# Altium schematic sheet MCU_ascii.SchDoc(MCU_ascii)
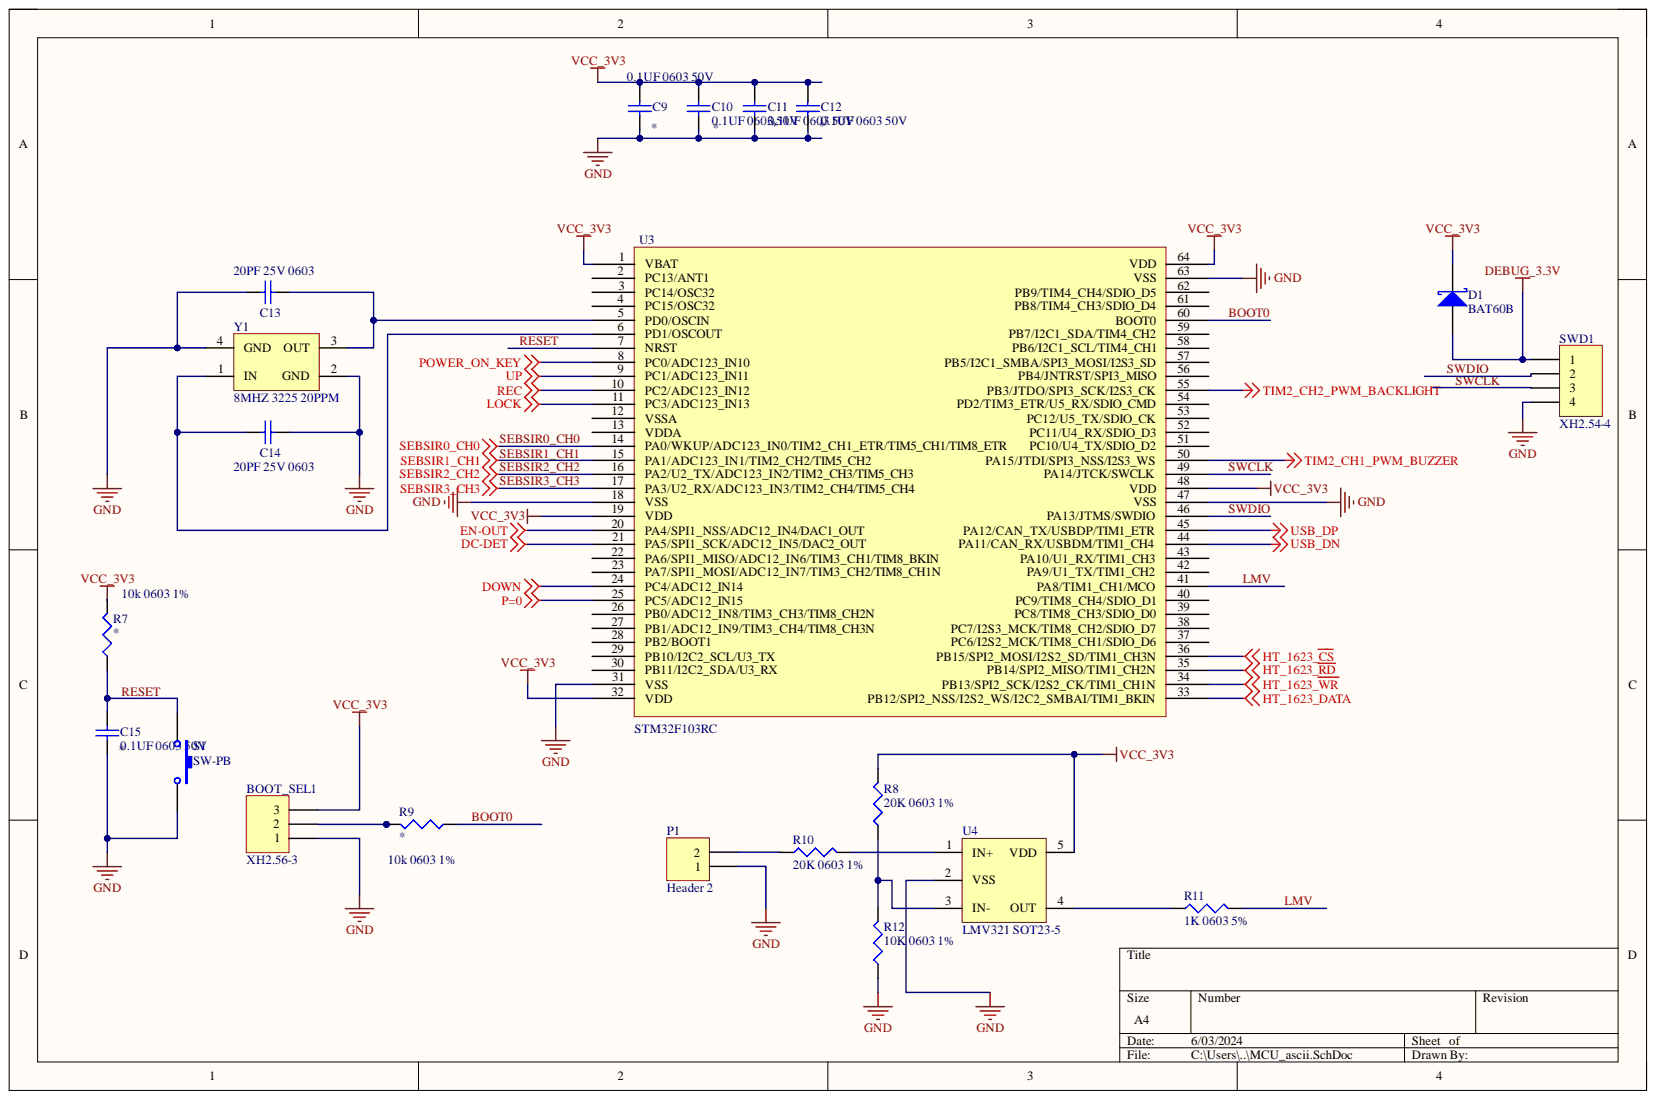
[diagram p0: MCU_ascii.SchDoc]

## Parts
BOOT_SEL1  XH2.56-3  [HDR1X3]
C9  0.1UF 0603 50V  [CAP0603_3D]
C10  0.1UF 0603 50V  封装: 0603  耐压: 50V  [CAP0603_3D]
C11  0.1UF 0603 50V  封装: 0603  耐压: 50V  [CAP0603_3D]
C12  0.1UF 0603 50V  封装: 0603  耐压: 50V  [CAP0603_3D]
C13  20PF 25V 0603  封装: 0603  [CAP0603_3D]
C14  20PF 25V 0603  封装: 0603  [CAP0603_3D]
C15  0.1UF 0603 50V  [CAP0603_3D]
D1  BAT60B  [SOD-323]
P1  Header 2  [HDR1X2]
R7  10k 0603 1%  [RES0603_3D]
R8  20K 0603 1%  [RES0603_3D]
R9  10k 0603 1%  [RES0603_3D]
R10  20K 0603 1%  精度误差: 1%  [RES0603_3D]
R11  1K 0603 5%  [RES0603_3D]
R12  10K 0603 1%  [RES0603_3D]
S1  SW-PB  [SPST-2]
SWD1  XH2.54-4  [HDR1X4]
U3  STM32F103RC  [LQFP64-ST]
U4  LMV321 SOT23-5  [SOT23-5]
Y1  8MHZ 3225 20PPM  [晶振3225_3D]

## Nets
DC-DET: U3.21(PA5/SPI1_SCK/ADC12_IN5/DAC2_OUT)
DEBUG_3.3V: D1.2 SWD1.1
DOWN: U3.24(PC4/ADC12_IN14)
EN-OUT: U3.20(PA4/SPI1_NSS/ADC12_IN4/DAC1_OUT)
GND: BOOT_SEL1.1 C9.1 C10.1 C11.1 C12.1 C13.1 C14.2 C15.1 P1.1 R12.1 S1.2 SWD1.4 U3.18(VSS) U3.31(VSS) U3.47(VSS) U3.63(VSS) U4.2(VSS) Y1.2(GND) Y1.4(GND)
HT_1623_~{CS}: U3.36(PB15/SPI2_MOSI/I2S2_SD/TIM1_CH3N)
HT_1623_DATA: U3.33(PB12/SPI2_NSS/I2S2_WS/I2C2_SMBAI/TIM1_BKIN)
HT_1623_~{RD}: U3.35(PB14/SPI2_MISO/TIM1_CH2N)
HT_1623_~{WR}: U3.34(PB13/SPI2_SCK/I2S2_CK/TIM1_CH1N)
LOCK: U3.11(PC3/ADC123_IN13)
P=0: U3.25(PC5/ADC12_IN15)
POWER_ON_KEY: U3.8(PC0/ADC123_IN10)
REC: U3.10(PC2/ADC123_IN12)
TIM2_CH1_PWM_BUZZER: U3.50(PA15/JTDI/SPI3_NSS/I2S3_WS)
TIM2_CH2_PWM_BACKLIGHT: U3.55(PB3/JTDO/SPI3_SCK/I2S3_CK)
UP: U3.9(PC1/ADC123_IN11)
USB_DN: U3.44(PA11/CAN_RX/USBDM/TIM1_CH4)
USB_DP: U3.45(PA12/CAN_TX/USBDP/TIM1_ETR)
VCC_3V3: BOOT_SEL1.3 C9.2 C10.2 C11.2 C12.2 D1.1 R7.2 R8.2 U3.1(VBAT) U3.19(VDD) U3.32(VDD) U3.48(VDD) U3.64(VDD) U4.5(VDD)
BOOT0: R9.1 U3.60(BOOT0)
LMV: R11.2 U3.41(PA8/TIM1_CH1/MCO)
RESET: C15.2 R7.1 S1.1 U3.7(NRST)
SEBSIR0_CH0: U3.14(PA0/WKUP/ADC123_IN0/TIM2_CH1_ETR/TIM5_CH1/TIM8_ETR)
SEBSIR1_CH1: U3.15(PA1/ADC123_IN1/TIM2_CH2/TIM5_CH2)
SEBSIR2_CH2: U3.16(PA2/U2_TX/ADC123_IN2/TIM2_CH3/TIM5_CH3)
SEBSIR3_CH3: U3.17(PA3/U2_RX/ADC123_IN3/TIM2_CH4/TIM5_CH4)
SWCLK: SWD1.3 U3.49(PA14/JTCK/SWCLK)
SWDIO: SWD1.2 U3.46(PA13/JTMS/SWDIO)
NetBOOT_SEL1_2: BOOT_SEL1.2 R9.2
NetC13_2: C13.2 U3.5(PD0/OSCIN) Y1.3(OUT)
NetC14_1: C14.1 U3.6(PD1/OSCOUT) Y1.1(IN)
NetP1_2: P1.2 R10.1
NetR8_1: R8.1 R12.2 U4.3(IN-)
NetR10_2: R10.2 U4.1(IN+)
NetR11_1: R11.1 U4.4(OUT)

Not connected: U3 2(PC13/ANT1) 3(PC14/OSC32) 4(PC15/OSC32) 12(VSSA) 13(VDDA) 22(PA6/SPI1_MISO/ADC12_IN6/TIM3_CH1/TIM8_BKIN) 23(PA7/SPI1_MOSI/ADC12_IN7/TIM3_CH2/TIM8_CH1N) 26(PB0/ADC12_IN8/TIM3_CH3/TIM8_CH2N) 27(PB1/ADC12_IN9/TIM3_CH4/TIM8_CH3N) 28(PB2/BOOT1) 29(PB10/I2C2_SCL/U3_TX) 30(PB11/I2C2_SDA/U3_RX) 37(PC6/I2S2_MCK/TIM8_CH1/SDIO_D6) 38(PC7/I2S3_MCK/TIM8_CH2/SDIO_D7) 39(PC8/TIM8_CH3/SDIO_D0) 40(PC9/TIM8_CH4/SDIO_D1) 42(PA9/U1_TX/TIM1_CH2) 43(PA10/U1_RX/TIM1_CH3) 51(PC10/U4_TX/SDIO_D2) 52(PC11/U4_RX/SDIO_D3) 53(PC12/U5_TX/SDIO_CK) 54(PD2/TIM3_ETR/U5_RX/SDIO_CMD) 56(PB4/JNTRST/SPI3_MISO) 57(PB5/I2C1_SMBA/SPI3_MOSI/I2S3_SD) 58(PB6/I2C1_SCL/TIM4_CH1) 59(PB7/I2C1_SDA/TIM4_CH2) 61(PB8/TIM4_CH3/SDIO_D4) 62(PB9/TIM4_CH4/SDIO_D5)

## Library data of the parts
BOOT_SEL1 = Header 3: Header, 3-Pin; Component Kind: Standard; LatestRevisionDate: 17-Jul-2002; LatestRevisionNote: Re-released for DXP Platform.; Library Name: Miscellaneous Connectors.IntLib; Signal Integrity: Connector; Publisher: Altium Limited
C9 C15 = CAP_SMT: 贴片多层陶瓷电容; 容值误差: 10%; 封装: 0603; Component Kind: Standard; 耐压: 50V; Library Name: hwt.SchLib
C10-C12 = CAP_SMT: 贴片多层陶瓷电容; 容值误差: 10%; Component Kind: Standard; Library Name: hwt.SchLib
C13 C14 = CAP_SMT: 贴片多层陶瓷电容; 容值误差: 10%; 耐压: 50V; Component Kind: Standard; Library Name: hwt.SchLib
D1 = BAT60B: Silicon AF Schottky Rectifier Diode; Component Kind: Standard; ComponentLink1Description: Manufacturer Link; ComponentLink1URL: http://www.infineon.com/; ComponentLink2Description: Datasheet; ComponentLink2URL: http://www.infineon.com/upload/Document/cmc_upload/documents/009/394/bat60bseries.pdf; DatasheetDocument: Jan-1999; LatestRevisionDate: 19-Mar-2004; LatestRevisionNote: Silkscreen size reduced.; Library Name: Infineon Discrete Diode.IntLib; PackageDocument: Sep-2000; PackageReference: SOD-323; Published: 21-Mar-2003; Publisher: Altium Limited
P1 = Header 2: Header, 2-Pin; Component Kind: Standard; LatestRevisionDate: 17-Jul-2002; LatestRevisionNote: Re-released for DXP Platform.; Library Name: Miscellaneous Connectors.IntLib; Signal Integrity: Connector; Publisher: Altium Limited
R7-R10 R12 = RES_SMT: Component Kind: Standard; Library Name: hwt.SchLib
  R7-R9 R12: 精度误差: 1%
R11 = RES_SMT: 精度误差: 1%; Component Kind: Standard; Library Name: hwt.SchLib
S1 = SW-PB: Switch; Component Kind: Standard; LatestRevisionDate: 17-Jul-2002; LatestRevisionNote: Re-released for DXP Platform.; Library Name: Miscellaneous Devices.IntLib; PackageDescription: Switch; 2 Leads; PackageReference: SPST-2; Published: 24-Mar-1999; Publisher: Altium Limited
SWD1 = Header 4: Header, 4-Pin; Component Kind: Standard; LatestRevisionDate: 17-Jul-2002; LatestRevisionNote: Re-released for DXP Platform.; Library Name: Miscellaneous Connectors.IntLib; Signal Integrity: Connector; Publisher: Altium Limited
Y1 = sx3225: Component Kind: Standard; Library Name: hwt.SchLib
U3 = STM32F103RC: Component Kind: Standard; Library Name: hwt.SchLib
U4 = LMV321 SOT23-5: Component Kind: Standard; Library Name: hwt.SchLib
